# Altium schematic sheet DriversBoard.SchDoc(DriversBoard)
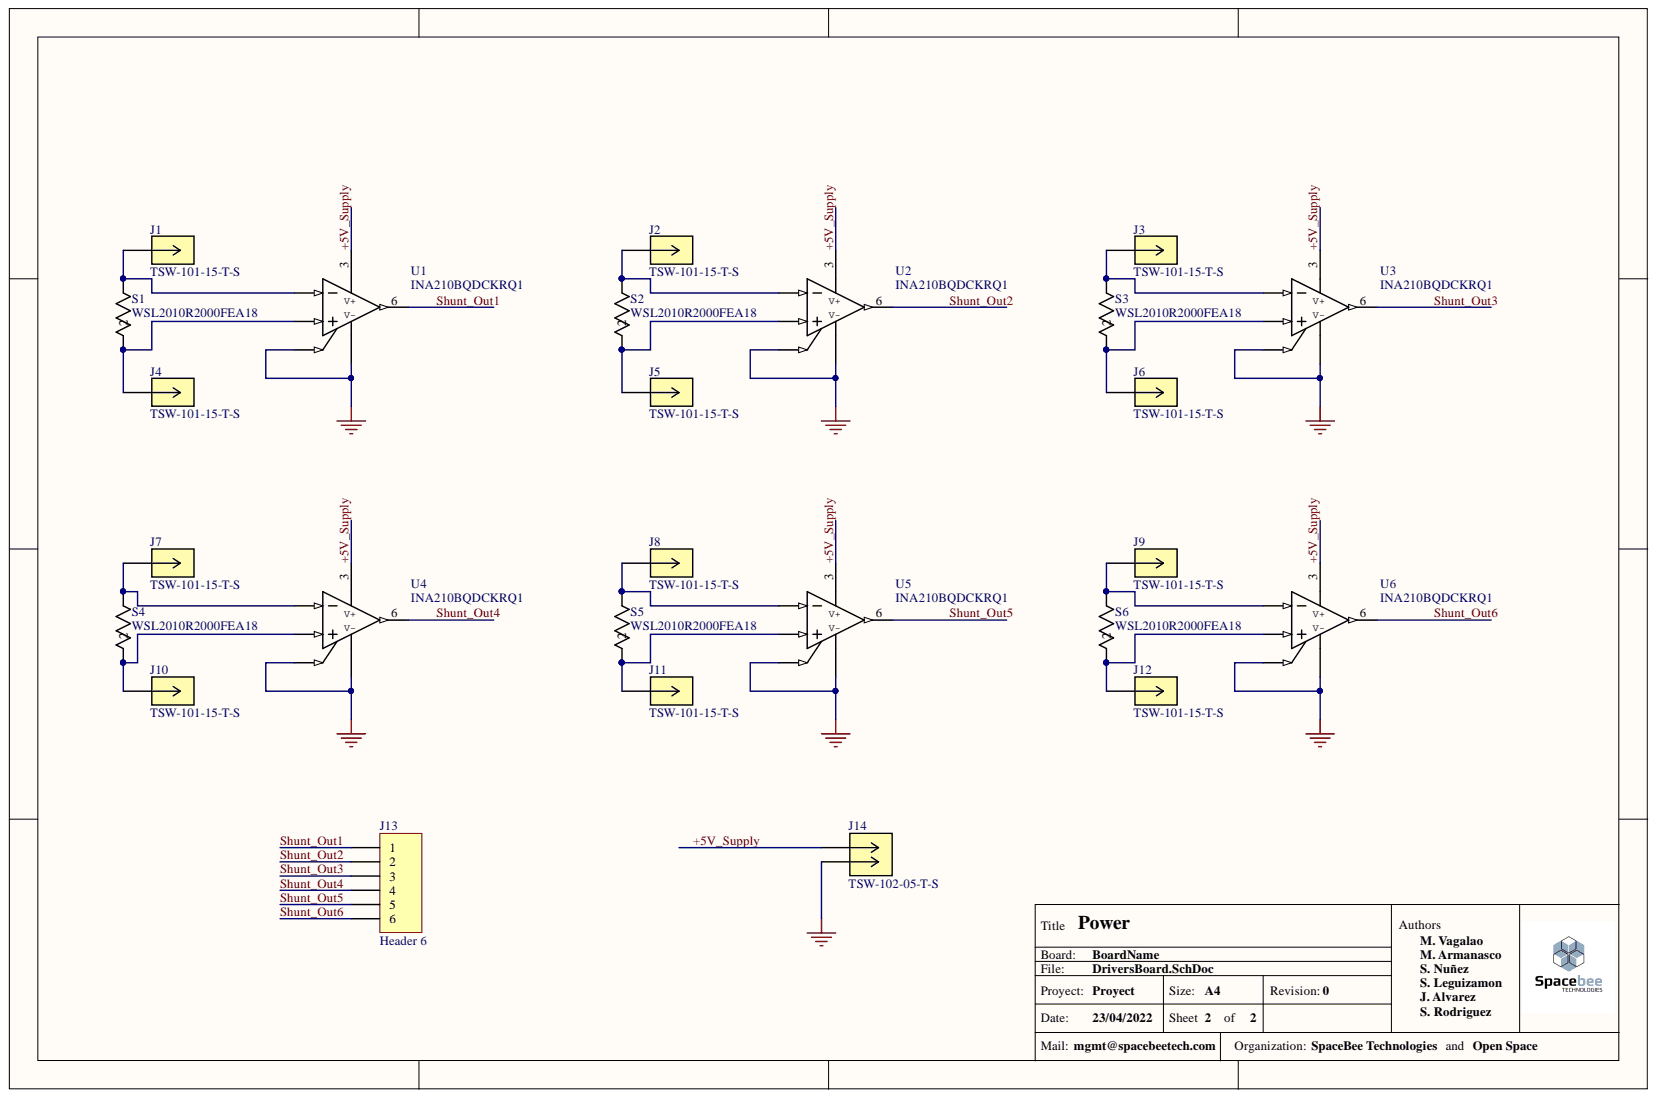
[diagram p0: DriversBoard.SchDoc]

## Parts
J1  TSW-101-15-T-S  [CON1_1X1_TU_TSW]
J2  TSW-101-15-T-S  [CON1_1X1_TU_TSW]
J3  TSW-101-15-T-S  [CON1_1X1_TU_TSW]
J4  TSW-101-15-T-S  [CON1_1X1_TU_TSW]
J5  TSW-101-15-T-S  [CON1_1X1_TU_TSW]
J6  TSW-101-15-T-S  [CON1_1X1_TU_TSW]
J7  TSW-101-15-T-S  [CON1_1X1_TU_TSW]
J8  TSW-101-15-T-S  [CON1_1X1_TU_TSW]
J9  TSW-101-15-T-S  [CON1_1X1_TU_TSW]
J10  TSW-101-15-T-S  [CON1_1X1_TU_TSW]
J11  TSW-101-15-T-S  [CON1_1X1_TU_TSW]
J12  TSW-101-15-T-S  [CON1_1X1_TU_TSW]
J13  Header 6  [HDR1X6]
J14  TSW-102-05-T-S  [CON2_1X2_TU_TSW]
S1  WSL2010R2000FEA18  [RC2010N_VIS]
S2  WSL2010R2000FEA18  [RC2010N_VIS]
S3  WSL2010R2000FEA18  [RC2010N_VIS]
S4  WSL2010R2000FEA18  [RC2010N_VIS]
S5  WSL2010R2000FEA18  [RC2010N_VIS]
S6  WSL2010R2000FEA18  [RC2010N_VIS]
U1  INA210BQDCKRQ1  [DCK0006A_L]
U2  INA210BQDCKRQ1  [DCK0006A_L]
U3  INA210BQDCKRQ1  [DCK0006A_L]
U4  INA210BQDCKRQ1  [DCK0006A_L]
U5  INA210BQDCKRQ1  [DCK0006A_L]
U6  INA210BQDCKRQ1  [DCK0006A_L]

## Nets
GND: J14.2 U1.1 U1.2(V-) U2.1 U2.2(V-) U3.1 U3.2(V-) U4.1 U4.2(V-) U5.1 U5.2(V-) U6.1 U6.2(V-)
+5V_Supply: J14.1 U1.3(V+) U2.3(V+) U3.3(V+) U4.3(V+) U5.3(V+) U6.3(V+)
Shunt_Out1: J13.1 U1.6
Shunt_Out2: J13.2 U2.6
Shunt_Out3: J13.3 U3.6
Shunt_Out4: J13.4 U4.6
Shunt_Out5: J13.5 U5.6
Shunt_Out6: J13.6 U6.6
NetJ1_1: J1.1 S1.1 U1.5
NetJ2_1: J2.1 S2.1 U2.5
NetJ3_1: J3.1 S3.1 U3.5
NetJ4_1: J4.1 S1.2 U1.4
NetJ5_1: J5.1 S2.2 U2.4
NetJ6_1: J6.1 S3.2 U3.4
NetJ7_1: J7.1 S4.1 U4.5
NetJ8_1: J8.1 S5.1 U5.5
NetJ9_1: J9.1 S6.1 U6.5
NetJ10_1: J10.1 S4.2 U4.4
NetJ11_1: J11.1 S5.2 U5.4
NetJ12_1: J12.1 S6.2 U6.4

## Library data of the parts
J1-J12 = TSW-101-15-T-S: No Description Available; Mfr_Name: Samtec Inc; Type: DEV; RefDes: RefDes; Manufacturer_Part_Number: TSW-101-15-T-S; Library Name: TSW-101-15-T-S.SchLib; Digi-Key_Part_Number_1: SAM12298-ND; Component Kind: Standard; Copyright: Copyright (C) 2022 Ultra Librarian. All rights reserved.
J13 = Header 6: Header, 6-Pin; Signal Integrity: Connector; Library Name: Miscellaneous Connectors.IntLib; LatestRevisionNote: Re-released for DXP Platform.; LatestRevisionDate: 17-Jul-2002; Component Kind: Standard; Publisher: Altium Limited
J14 = TSW-102-05-T-S: No Description Available; Mfr_Name: Samtec Inc; Type: DEV; RefDes: RefDes; Manufacturer_Part_Number: TSW-102-05-T-S; Library Name: TSW-102-05-T-S.SchLib; Digi-Key_Part_Number_3: 612-TSW-102-05-T-S-ND; Digi-Key_Part_Number_2: TSW-102-05-T-S-NDL; Digi-Key_Part_Number_1: SAM1019-02-ND; Component Kind: Standard; Copyright: Copyright (C) 2022 Ultra Librarian. All rights reserved.
S1-S6 = WSL2010R2000FEA18: No Description Available; Mfr_Name: Vishay; Type: DEV; RefDes: RefDes; Manufacturer_Part_Number: WSL2010R2000FEA18; Library Name: WSL2010R2000FEA18.SchLib; Component Kind: Standard; Copyright: Copyright (C) 2022 Ultra Librarian. All rights reserved.
U1-U6 = INA210BQDCKRQ1: No Description Available; Mfr_Name: Texas Instruments; Type: DEV; RefDes: RefDes; Manufacturer_Part_Number: INA210BQDCKRQ1; Library Name: INA210BQDCKRQ1.SchLib; Component Kind: Standard; Copyright: Copyright (C) 2022 Ultra Librarian. All rights reserved.
